# Altium schematic sheet Dental Support V400.SchDoc("Dental Support V400")
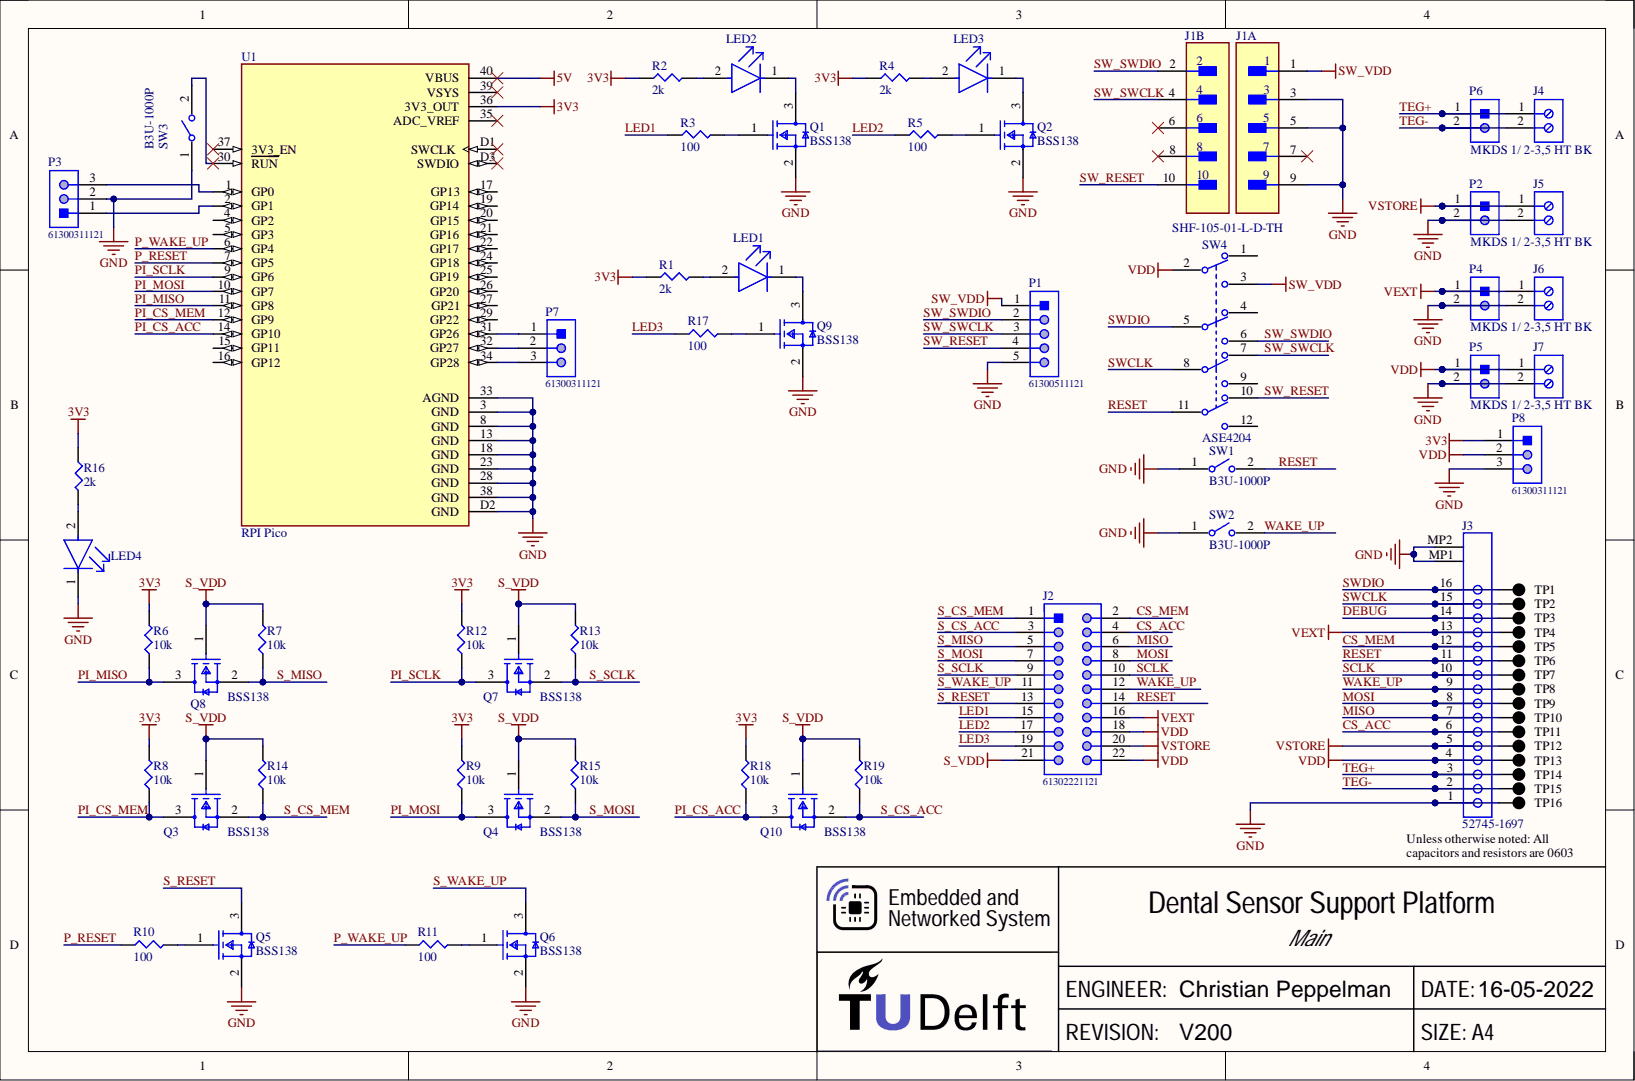
[diagram p0: Dental Support V400.SchDoc]

## Parts
J1  SHF-105-01-L-D-TH  [FP-SHF-105-01-L-D-TH-MFG]  (parts A,B)
J2  61302221121  [61302221121]
J3  52745-1697  [MOLX-52745-1697]
J4  MKDS 1/ 2-3,5 HT BK  [MKDS 1/ 2-3,5 HT BK]
J5  MKDS 1/ 2-3,5 HT BK  [MKDS 1/ 2-3,5 HT BK]
J6  MKDS 1/ 2-3,5 HT BK  [MKDS 1/ 2-3,5 HT BK]
J7  MKDS 1/ 2-3,5 HT BK  [MKDS 1/ 2-3,5 HT BK]
LED1  150080SS75000  [FP-150080SS75000-MFG]  (value not drawn)
LED2  150080SS75000  [FP-150080SS75000-MFG]  (value not drawn)
LED3  150080SS75000  [FP-150080SS75000-MFG]  (value not drawn)
LED4  150080SS75000  [FP-150080SS75000-MFG]  (value not drawn)
P1  61300511121  [61300511121]
P2  61300211121  [61300211121]  (value not drawn)
P3  61300311121  [61300311121]
P4  61300211121  [61300211121]  (value not drawn)
P5  61300211121  [61300211121]  (value not drawn)
P6  61300211121  [61300211121]  (value not drawn)
P7  61300311121  [61300311121]
P8  61300311121  [61300311121]
Q1  BSS138  [FP-318-08-IPC_B]
Q2  BSS138  [FP-318-08-IPC_B]
Q3  BSS138  [FP-318-08-IPC_B]
Q4  BSS138  [FP-318-08-IPC_B]
Q5  BSS138  [FP-318-08-IPC_B]
Q6  BSS138  [FP-318-08-IPC_B]
Q7  BSS138  [FP-318-08-IPC_B]
Q8  BSS138  [FP-318-08-IPC_B]
Q9  BSS138  [FP-318-08-IPC_B]
Q10  BSS138  [FP-318-08-IPC_B]
R1  2k  [RESC2012X06N]
R2  2k  [RESC2012X06N]
R3  100  [RESC2012X06N]
R4  2k  [RESC2012X06N]
R5  100  [RESC2012X06N]
R6  10k  [RESC2012X06N]
R7  10k  [RESC2012X06N]
R8  10k  [RESC2012X06N]
R9  10k  [RESC2012X06N]
R10  100  [RESC2012X06N]
R11  100  [RESC2012X06N]
R12  10k  [RESC2012X06N]
R13  10k  [RESC2012X06N]
R14  10k  [RESC2012X06N]
R15  10k  [RESC2012X06N]
R16  2k  [RESC2012X06N]
R17  100  [RESC2012X06N]
R18  10k  [RESC2012X06N]
R19  10k  [RESC2012X06N]
SW1  B3U-1000P  [FP-B3U-1000P-MFG]
SW2  B3U-1000P  [FP-B3U-1000P-MFG]
SW3  B3U-1000P  [FP-B3U-1000P-MFG]
SW4  ASE4204  [FP-ASE4204-MFG]
TP1  5011  [KSTN-5011_V]  (value not drawn)
TP2  5011  [KSTN-5011_V]  (value not drawn)
TP3  5011  [KSTN-5011_V]  (value not drawn)
TP4  5011  [KSTN-5011_V]  (value not drawn)
TP5  5011  [KSTN-5011_V]  (value not drawn)
TP6  5011  [KSTN-5011_V]  (value not drawn)
TP7  5011  [KSTN-5011_V]  (value not drawn)
TP8  5011  [KSTN-5011_V]  (value not drawn)
TP9  5011  [KSTN-5011_V]  (value not drawn)
TP10  5011  [KSTN-5011_V]  (value not drawn)
TP11  5011  [KSTN-5011_V]  (value not drawn)
TP12  5011  [KSTN-5011_V]  (value not drawn)
TP13  5011  [KSTN-5011_V]  (value not drawn)
TP14  5011  [KSTN-5011_V]  (value not drawn)
TP15  5011  [KSTN-5011_V]  (value not drawn)
TP16  5011  [KSTN-5011_V]  (value not drawn)
U1  RPI Pico  [RPI Pico]

## Nets
3V3: P8.1 R1.1 R2.1 R4.1 R6.2 R8.2 R9.2 R12.2 R16.1 R18.2 U1.36(3V3_OUT)
5V: U1.40(VBUS)
GND: J1.3 J1.5 J1.9 J3.1 J3.MP1 J3.MP2 J5.2 J6.2 J7.2 LED4.1 P1.5 P2.2 P3.2 P4.2 P5.2 P8.3 Q1.2 Q2.2 Q5.2 Q6.2 Q9.2 SW1.1 SW2.1 SW3.1 TP16.1 U1.3(GND) U1.8(GND) U1.13(GND) U1.18(GND) U1.23(GND) U1.28(GND) U1.33(AGND) U1.38(GND) U1.D2(GND)
S_VDD: J2.21 Q3.1 Q4.1 Q7.1 Q8.1 Q10.1 R7.2 R13.2 R14.2 R15.2 R19.2
SW_VDD: J1.1 P1.1 SW4.3
VDD: J2.18 J2.22 J3.4 J7.1 P5.1 P8.2 SW4.2 TP13.1
VEXT: J2.16 J3.13 J6.1 P4.1 TP4.1
VSTORE: J2.20 J3.5 J5.1 P2.1 TP12.1
CS_ACC: J2.4 J3.6 TP11.1
CS_MEM: J2.2 J3.12 TP5.1
DEBUG: J3.14 TP3.1
LED1: J2.15 R3.1
LED2: J2.17 R5.1
LED3: J2.19 R17.1
MISO: J2.6 J3.7 TP10.1
MOSI: J2.8 J3.8 TP9.1
P_RESET: R10.1 U1.7(GP5)
P_WAKE_UP: R11.1 U1.6(GP4)
PI_CS_ACC: Q10.3 R18.1 U1.14(GP10)
PI_CS_MEM: Q3.3 R8.1 U1.12(GP9)
PI_MISO: Q8.3 R6.1 U1.11(GP8)
PI_MOSI: Q4.3 R9.1 U1.10(GP7)
PI_SCLK: Q7.3 R12.1 U1.9(GP6)
RESET: J2.14 J3.11 SW1.2 SW4.11 TP6.1
S_CS_ACC: J2.3 Q10.2 R19.1
S_CS_MEM: J2.1 Q3.2 R14.1
S_MISO: J2.5 Q8.2 R7.1
S_MOSI: J2.7 Q4.2 R15.1
S_RESET: J2.13 Q5.3
S_SCLK: J2.9 Q7.2 R13.1
S_WAKE_UP: J2.11 Q6.3
SCLK: J2.10 J3.10 TP7.1
SW_RESET: J1.10 P1.4 SW4.10
SW_SWCLK: J1.4 P1.3 SW4.7
SW_SWDIO: J1.2 P1.2 SW4.6
SWCLK: J3.15 SW4.8 TP2.1
SWDIO: J3.16 SW4.5 TP1.1
TEG+: J3.3 J4.1 P6.1 TP14.1
TEG-: J3.2 J4.2 P6.2 TP15.1
WAKE_UP: J2.12 J3.9 SW2.2 TP8.1
NetLED1_1: LED1.1 Q9.3
NetLED1_2: LED1.2 R1.2
NetLED2_1: LED2.1 Q1.3
NetLED2_2: LED2.2 R2.2
NetLED3_1: LED3.1 Q2.3
NetLED3_2: LED3.2 R4.2
NetLED4_2: LED4.2 R16.2
NetP3_1: P3.1 U1.2(GP1)
NetP3_3: P3.3 U1.1(GP0)
NetP7_1: P7.1 U1.31(GP26)
NetP7_2: P7.2 U1.32(GP27)
NetP7_3: P7.3 U1.34(GP28)
NetQ1_1: Q1.1 R3.2
NetQ2_1: Q2.1 R5.2
NetQ5_1: Q5.1 R10.2
NetQ6_1: Q6.1 R11.2
NetQ9_1: Q9.1 R17.2
NetSW3_2: SW3.2 U1.30(RUN)

Not connected: J1 6 7 8; SW4 1 4 9 12; U1 4(GP2) 5(GP3) 15(GP11) 16(GP12) 17(GP13) 19(GP14) 20(GP15) 21(GP16) 22(GP17) 24(GP18) 25(GP19) 26(GP20) 27(GP21) 29(GP22) 35(ADC_VREF) 37(3V3_EN) 39(VSYS) D1(SWCLK) D3(SWDIO)

## Library data of the parts
J1 = CMP-02766-000059-1: CONN HEADER VERT 10POS 1.27MM; Manufacturer: Samtec; Conn Gender: Male; Conn Orientation: Vertical; Number Of Contacts: 10; Standoff Height: 0.38mm; Automotive: No; Category: Conn; Component Kind: Standard; ComponentLink2Description: Datasheet; ComponentLink2URL: http://suddendocs.samtec.com/prints/shf-1xx-01-x-d-xx-xx-mkt.pdf; Contact Material: Gold,Nickel; Device Class L1: Connectors; Device Class L2: Headers and Wire Housings; Device Class L3: unset; Digikey Description: CONN HEADER VERT 10POS 1.27MM; Footprint Url: http://suddendocs.samtec.com/prints/shf-1xx-01-x-d-xx-xx-footprint.pdf; Height: 5.46mm; Lead Free: Yes; Library Name: Altium Content Vault; Manufacturer Part Number: SHF-105-01-L-D-TH; Mouser Description: Conn Shrouded Header (4 Sides) HDR 10 POS 1.27mm Solder ST Thru-Hole Tube; Number Of Rows: 2; Octopart: https://octopart.com/shf-105-01-l-d-th-samtec-17904677; Package: 0; Pitch: 1.27mm; RoHS: TRUE; Temperature: -55°C to +125°C; Temperature Range High: +125°C; Temperature Range Low: -55°C
J2 = CMP-1502-01108-1: THT Vertical Pin Header WR-PHD, Pitch 2.54 mm, Dual Row, 22 pins; Manufacturer: Wurth Elektronik; Contact Resistance: 20mOhm; Gender: Male; Pin Number: 22; Pitch: 2.54mm; Rated Current: 3A; Withstanding Voltage: 500VAC; Working Voltage: 250VAC; Component Kind: Standard; Datasheet URL: https://katalog.we-online.de/em/datasheet/6130xx21121.pdf; Library Name: Altium Content Vault; Manufacturer URL: http://www.we-online.de; Mounting Technology: THT; Operating Temperature: -40to105degC; Orientation: Vertical; Package Reference: 61302221121; Packaging: Bag; Part Number: 61302221121; Row Number: Dual; Publisher: WurthElektronik
J3 = CMP-1241-00362-1: 0.5 mm Pitch Easy-On(TM) Type FPC Connector, 2.0 mm Mated Height, Right Angle, ZIF, SMT, Top Contact, 16 Circuits, -20 to 85 degC, ELV and RoHS Compliant, Tape and Reel; Manufacturer: Molex; Circuits (Loaded): 16; Current (Max per Contact): 0.5A; Durability (mating cycles max): 20; Entry Angle: 90degrees Angle; Mated Height: 2.00mm; Material - Metal: Phosphor Bronze; Material - Plating Mating: Gold; PCB Locator: No; PCB Retention: Yes; Pitch - Mating Interface: 0.50mm; Voltage (Max): 50V; Component Kind: Standard; ComponentLink1Description: Manufacturer URL; ComponentLink1URL: http://www.molex.com/molex/index.jsp; ComponentLink2Description: Datasheet; ComponentLink2URL: http://www.molex.com/webdocs/datasheets/pdf/en-us/0527451697_FFC_FPC_CONNECTORS.pdf; ComponentLink3Description: Package Specification; ComponentLink3URL: http://www.molex.com/pdm_docs/sd/527451697_sd.pdf; Contact Position: Top; Library Name: Altium Content Vault; Material - Plating Termination: Gold; Mounting Technology: Surface Mount; Number of Rows: 1; Orientation: Right Angle; PackageDescription: 16-Lead FPC Connector, Pitch 0.5 mm; PackageReference: 52745-1697; PackageVersion: Rev. C, 07/2010; Packing: Tape and Reel; PartNumber: 52745-1697; Polarized to PCB: Yes; RoHS: TRUE; Stackable: No
LED1-LED4 = CMP-1426-00010-2: LED RED CLEAR 0805 SMD; Dominant Wavelength: 630nm; Forward Current: 30mA; Forward Voltage: 1.9V; Lens Color: Colorless; Lens Size: 1.25mm; Luminous Intensity: 60mcd; Test Current: 20mA; Viewing Angle: 140°; Case Code (Imperial): 0805; Case Code (Metric): 2012; Case/Package: 0805; Color: Red; Component Kind: Standard; Height: 0.8mm; Length: 2mm; Lens Style: Clear; Lens Transparency: Clear; Library Name: Altium Content Vault; Max Operating Temperature: 85°C; Min Operating Temperature: -40°C; Mount: Surface Mount; Package Quantity: 1; Packaging: Cut Tape; RoHS Compliant: Yes; Series: WL-SMCW; Wavelength: 630nm; Width: 1.25mm
P1 = CMP-1502-01068-1: THT Vertical Pin Header WR-PHD, Pitch 2.54 mm, Single Row, 5 pins; Manufacturer: Wurth Elektronik; Contact Resistance: 20mOhm; Gender: Male; Pin Number: 5; Pitch: 2.54mm; Rated Current: 3A; Withstanding Voltage: 500VAC; Working Voltage: 250VAC; Component Kind: Standard; Datasheet URL: https://katalog.we-online.de/em/datasheet/6130xx11121.pdf; Library Name: Altium Content Vault; Manufacturer URL: http://www.we-online.de; Mounting Technology: THT; Operating Temperature: -40to105degC; Orientation: Vertical; Package Reference: 61300511121; Packaging: Bag; Part Number: 61300511121; Row Number: Single; Publisher: WurthElektronik
P2 P4-P6 = CMP-1712-00002-4: THT Vertical Pin Header WR-PHD, Pitch 2.54 mm, Single Row, 2 pins; Manufacturer: Wurth Elektronik; Contact Resistance: 20mOhm; Gender: Male; Pin Number: 2; Pitch: 2.54mm; Rated Current: 3A; Withstanding Voltage: 500VAC; Working Voltage: 250VAC; Component Kind: Standard; Datasheet URL: https://katalog.we-online.de/em/datasheet/6130xx11121.pdf; Library Name: Altium Content Vault; Manufacturer URL: http://www.we-online.de; Mounting Technology: THT; Operating Temperature: -40to105degC; Orientation: Vertical; Package Reference: 61300211121; Packaging: Bag; Part Number: 61300211121; Row Number: Single; Publisher: WurthElektronik
P3 P7 P8 = CMP-1502-01062-1: THT Vertical Pin Header WR-PHD, Pitch 2.54 mm, Single Row, 3 pins; Manufacturer: Wurth Elektronik; Contact Resistance: 20mOhm; Gender: Male; Pin Number: 3; Pitch: 2.54mm; Rated Current: 3A; Withstanding Voltage: 500VAC; Working Voltage: 250VAC; Component Kind: Standard; Datasheet URL: https://katalog.we-online.de/em/datasheet/6130xx11121.pdf; Library Name: Altium Content Vault; Manufacturer URL: http://www.we-online.de; Mounting Technology: THT; Operating Temperature: -40to105degC; Orientation: Vertical; Package Reference: 61300311121; Packaging: Bag; Part Number: 61300311121; Row Number: Single; Publisher: WurthElektronik
Q1-Q10 = CMP-07173-000101-1: MOSFET N-CH 50V 220MA SOT-23; Drain to Source Resistance: 3.5R; Element Configuration: Single; Fall Time: 9ns; Gate to Source Voltage (Vgs): 20V; Input Capacitance: 27pF; Max Power Dissipation: 360mW; Nominal Vgs: 1.3V; Number of Elements: 1; On-State Resistance: 6R; Turn-Off Delay Time: 20ns; Turn-On Delay Time: 2.5ns; Case/Package: SOT-23; Component Kind: Standard; Contact Plating: Tin; Continuous Drain Current (ID): 220mA; Current Rating: 220mA; Drain to Source Breakdown Voltage: 50V; Drain to Source Voltage (Vdss): 50V; Dual Supply Voltage: 50V; Height: 0.93mm; Lead Free: Lead Free; Length: 2.92mm; Library Name: Altium Content Vault; Max Operating Temperature: 150°C; Min Operating Temperature: -55°C; Mount: Surface Mount; Number of Pins: 3; Package Quantity: 1; Packaging: Tape and Reel; Power Dissipation: 360mW; Radiation Hardening: No; Rds On Max: 3.5R; REACH SVHC: No SVHC; Rise Time: 9ns; Termination: SMD/SMT; Threshold Voltage: 1.3V; Voltage Rating (DC): 50V; Weight: 0.03g; Width: 1.3mm
R1 R2 R4 R16 = ERJ-6ENF2001V: RES SMD 2K OHM 1% 1/8W 0805; Manufacturer: Panasonic Electronic Components; @ qty: 1500; Factory Stock: 0; Minimum Quantity: 1; Power (Watts): 0.125W, 1/8W; Temperature Coefficient: ±100ppm/°C; Unit Price (USD): 0.00729; Component Kind: Standard; Composition: Thick Film; Datasheets: http://industrial.panasonic.com/www-cgi/jvcr13pz.cgi?E+PZ+3+AOA0002+ERJ6ENF2001V+7+WW; Digi-Key Part Number: P2.00KCCT-ND; Failure Rate: -; Features: Automotive AEC-Q200; Height - Seated (Max): 0.028" (0.70mm); Image: //media.digikey.com/Renders/Panasonic%20Renders/ERJ-0805%20Pkg.jpg; Library Name: Panasonic_ERJ_0805.IntLib; Number of Terminations: 2; Operating Temperature: -55°C ~ 155°C; Package / Case: 0805 (2012 Metric); Packaging: Cut Tape (CT); Part Number: ERJ-6ENF2001V; Part Status: Active; Quantity Available: 732688; Series: ERJ; Size / Dimension: 0.079" L x 0.049" W (2.00mm x 1.25mm); Supplier Device Package: 0805; Tolerance: ±1%; Value: 2k
R3 R5 R10 R11 R17 = ERJ-6ENF1000V: RES SMD 100 OHM 1% 1/8W 0805; Manufacturer: Panasonic Electronic Components; @ qty: 1500; Factory Stock: 0; Minimum Quantity: 1; Power (Watts): 0.125W, 1/8W; Temperature Coefficient: ±100ppm/°C; Unit Price (USD): 0.00729; Component Kind: Standard; Composition: Thick Film; Datasheets: http://industrial.panasonic.com/www-cgi/jvcr13pz.cgi?E+PZ+3+AOA0002+ERJ6ENF1000V+7+WW; Digi-Key Part Number: P100CCT-ND; Failure Rate: -; Features: Automotive AEC-Q200; Height - Seated (Max): 0.028" (0.70mm); Image: //media.digikey.com/Renders/Panasonic%20Renders/ERJ-0805%20Pkg.jpg; Library Name: Panasonic_ERJ_0805.IntLib; Number of Terminations: 2; Operating Temperature: -55°C ~ 155°C; Package / Case: 0805 (2012 Metric); Packaging: Cut Tape (CT); Part Number: ERJ-6ENF1000V; Part Status: Active; Quantity Available: 288663; Series: ERJ; Size / Dimension: 0.079" L x 0.049" W (2.00mm x 1.25mm); Supplier Device Package: 0805; Tolerance: ±1%; Value: 100
R6-R9 R12-R15 R18 R19 = ERJ-6ENF1002V: RES SMD 10K OHM 1% 1/8W 0805; Manufacturer: Panasonic Electronic Components; @ qty: 1500; Factory Stock: 0; Minimum Quantity: 1; Power (Watts): 0.125W, 1/8W; Temperature Coefficient: ±100ppm/°C; Unit Price (USD): 0.00729; Component Kind: Standard; Composition: Thick Film; Datasheets: http://industrial.panasonic.com/www-cgi/jvcr13pz.cgi?E+PZ+3+AOA0002+ERJ6ENF1002V+7+WW; Digi-Key Part Number: P10.0KCCT-ND; Failure Rate: -; Features: Automotive AEC-Q200; Height - Seated (Max): 0.028" (0.70mm); Image: //media.digikey.com/Renders/Panasonic%20Renders/ERJ-0805%20Pkg.jpg; Library Name: Panasonic_ERJ_0805.IntLib; Number of Terminations: 2; Operating Temperature: -55°C ~ 155°C; Package / Case: 0805 (2012 Metric); Packaging: Cut Tape (CT); Part Number: ERJ-6ENF1002V; Part Status: Active; Quantity Available: 3985614; Series: ERJ; Size / Dimension: 0.079" L x 0.049" W (2.00mm x 1.25mm); Supplier Device Package: 0805; Tolerance: ±1%; Value: 10k
SW1-SW3 = CMP-2100-03586-2: SWITCH TACTILE SPST-NO 0.05A 12V; Actuator Length: 0.4mm; Actuator Type: Standard; Contact Current Rating: 50mA; Contact Resistance: 100mR; Electrical Life: 200000Cycles; Illumination Type: Non-Illuminated; Operating Force: 1.5N; Ratings: Dust Proof; Switch Function: Off-Mom; Switch Travel: 0.15mm; Throw Configuration: SPST; Component Kind: Standard; Contact Material: Silver; Current Rating: 50mA; Depth: 2.5mm; Height: 1.2mm; Insulation Resistance: 100MR; Lead Free: Lead Free; Length: 4mm; Library Name: Altium Content Vault; Max Current Rating: 50mA; Max Operating Temperature: 70°C; Max Voltage Rating (DC): 12V; Mechanical Life: 200000Cycles; Min Operating Temperature: -25°C; Mount: Surface Mount; Orientation: Straight; Package Quantity: 1; Packaging: Tape and Reel; Termination: SMD/SMT; Voltage Rating (DC): 12V; Weight: 0.000776oz; Width: 3mm
SW4 = CMP-03405-000007-1: Pushbutton Switch SPST-NO Keyswitch, Illuminated Through Hole; Actuator Color: Natural; Actuator Material: Polyester; Actuator Type: SLIDE; Contact Current Rating: 300mA; Contact Resistance: 40mR; Electrical Life: 15000Cycles; Max Voltage Rating (DC): 115V; Number of Poles: 4; Number of Positions: 2; Throw Configuration: 4PDT; Color: Natural; Component Kind: Standard; Contact Material: Copper Alloy; Contact Plating: Silver; Current Rating: 300mA; Flammability Rating: UL94 V-0; Lead Free: Lead Free; Library Name: Altium Content Vault; Max Operating Temperature: 80°C; Min Operating Temperature: -40°C; Mount: Through Hole; Orientation: Vertical; Packaging: Rail/Tube; REACH SVHC: No SVHC; RoHS Compliant: Yes; Termination: Solder; Voltage Rating (AC): 115V
TP1-TP16 = CMP-1672-00003-4: Test Point, Black, Through Hole, RoHS, Bulk; Manufacturer: Keystone; Colour: Black; Component Kind: Standard; ComponentLink1Description: Manufacturer URL; ComponentLink1URL: http://www.keyelco.com/; ComponentLink2Description: Datasheet; ComponentLink2URL: http://www.keyelco.com/product-pdf.cfm?p=1320; Contact Material: Bronze; Contact Plating: Silver; DatasheetVersion: Rev. F, 12/2013; Height: 8.12 mm; Library Name: Altium Content Vault; Mount: Through Hole; Mounting Technology: Through Hole; Package Quantity: 100; PackageDescription: 1-Pin Through Hole Device, Dia 3.17 mm; PackageReference: 5011; Packaging: Bulk; Pins: 1; REACH SVHC: No SVHC; RoHSCompliant: true
J4-J7 = MKDS 1/ 2-3,5 HT BK: Component Kind: Standard; Library Name: Library.SchLib
U1 = RPI Pico: Component Kind: Standard; Library Name: Library.SchLib
